# Altium schematic sheet 06_Ethernet_Memory_Expansion.SchDoc
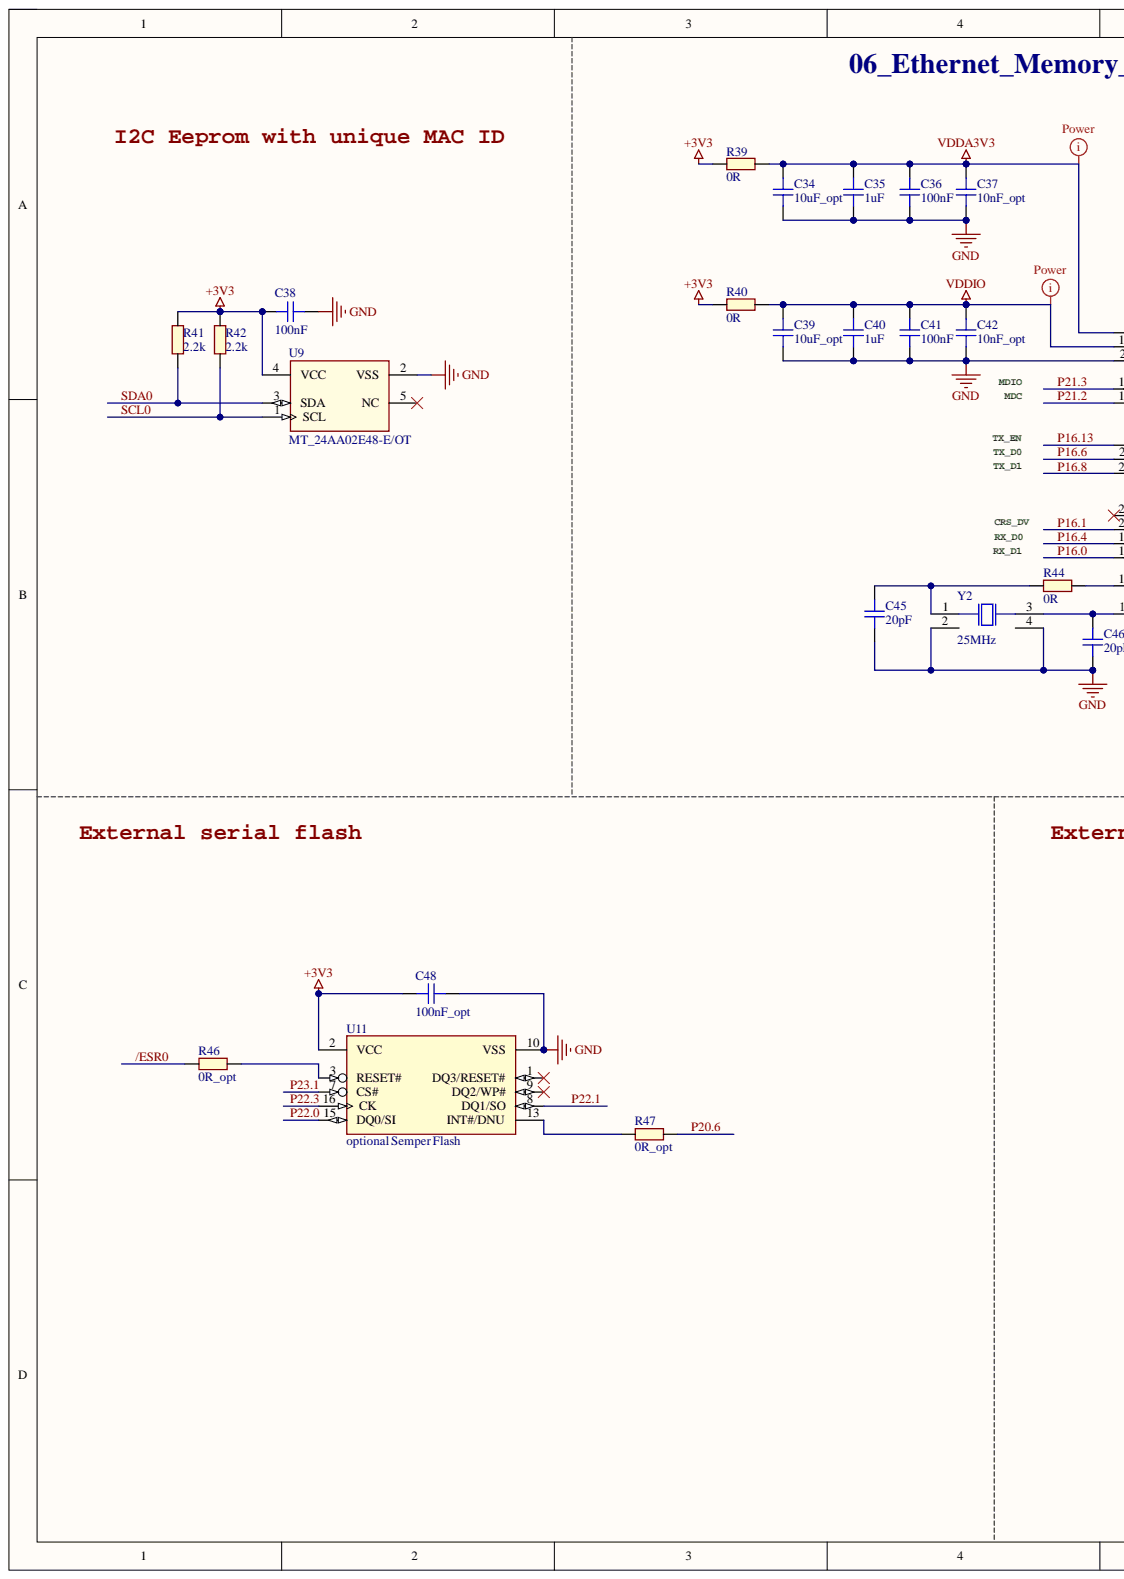
[diagram p0: 06_Ethernet_Memory_Expansion.SchDoc, left]
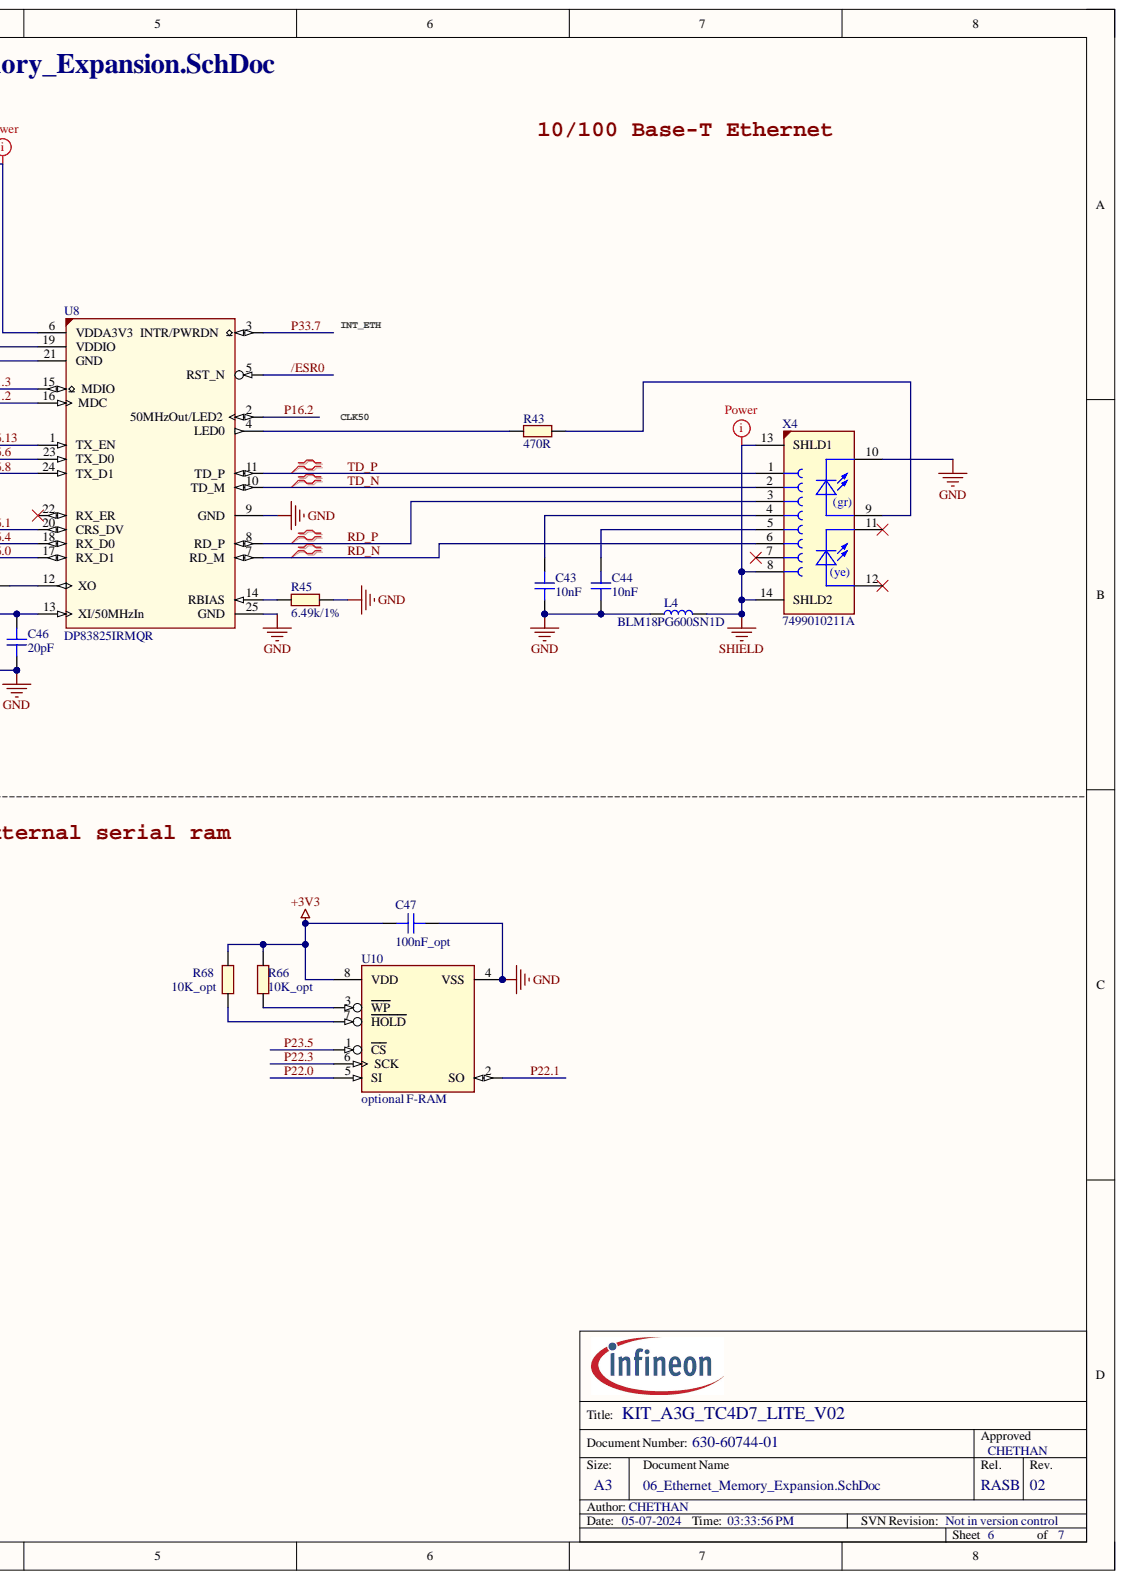
[diagram p1: 06_Ethernet_Memory_Expansion.SchDoc, right]

## Parts
C34  10uF_opt  [CAPC1005X70N]
C35  1uF  [CAPC1005X55N]
C36  100nF  [CAPC1005X55N-1]
C37  10nF_opt  [CAPC1005X55N]
C38  100nF  [CAPC1005X55N-1]
C39  10uF_opt  [CAPC1005X70N]
C40  1uF  [CAPC1005X55N]
C41  100nF  [CAPC1005X55N-1]
C42  10nF_opt  [CAPC1005X55N]
C43  10nF  [CAPC1005X55N]
C44  10nF  [CAPC1005X55N]
C45  20pF  [CAPC1005X55N-0]
C46  20pF  [CAPC1005X55N-0]
C47  100nF_opt  [CAPC1608X90N-4]
C48  100nF_opt  [CAPC1608X90N-4]
L4  BLM18PG600SN1D  [INDC1608X95N-2]
R39  0R  [RESC1609X50N]
R40  0R  [RESC1609X50N]
R41  2.2k  [RESC1609X50N]
R42  2.2k  [RESC1609X50N]
R43  470R  [RESC1005X40N]
R44  0R  [RESC1609X50N]
R45  6.49k/1%  [RESC1005X40N]
R46  0R_opt  [RESC1609X50N]
R47  0R_opt  [RESC1609X50N]
R66  10K_opt  [RESC1609X50N]
R68  10K_opt  [RESC1609X50N]
U8  DP83825IRMQR  [QFN40P300X300X80-25N]
U9  MT_24AA02E48-E/OT  [SOT95P270X145-5N-1]
U10  optional F-RAM  [SOIC127P800X203-8N]
U11  optional Semper Flash  [SOIC127P1030X265-16N-1]
X4  7499010211A  [CON-THT-RJ45-7499010211A]
Y2  25MHz  [XTAL-SMD-FA-238_1]

## Nets
+3V3: C38.1 C47.1 C48.1 R39.1 R40.1 R41.1 R42.1 R66.2 R68.2 U9.4(VCC) U10.8(VDD) U11.2(VCC)
GND: C34.1 C35.2 C36.1 C37.2 C38.2 C39.1 C40.2 C41.2 C42.2 C43.2 C44.2 C45.2 C46.2 C47.2 C48.2 L4.1 R45.2 U8.9(GND) U8.21(GND) U8.25(GND) U9.2(VSS) U10.4(VSS) U11.10(VSS) X4.10 Y2.2 Y2.4
SHIELD: L4.2 X4.8 X4.13(SHLD1) X4.14(SHLD2)
VDDA3V3: C34.2 C35.1 C36.2 C37.1 R39.2 U8.6(VDDA3V3)
VDDIO: C39.2 C40.1 C41.1 C42.1 R40.2 U8.19(VDDIO)
/ESR0: R46.2 U8.5(RST_N)
P16.0: U8.17(RX_D1)
P16.1: U8.20(CRS_DV)
P16.2: U8.2(50MHzOut/LED2)
P16.4: U8.18(RX_D0)
P16.6: U8.23(TX_D0)
P16.8: U8.24(TX_D1)
P16.13: U8.1(TX_EN)
P20.6: R47.1
P21.2: U8.16(MDC)
P21.3: U8.15(MDIO)
P22.0: U10.5(SI) U11.15(DQ0/SI)
P22.1: U10.2(SO) U11.8(DQ1/SO)
P22.3: U10.6(SCK) U11.16(CK)
P23.1: U11.7(CS#)
P23.5: U10.1(CS)
P33.7: U8.3(INTR/PWRDN)
RD_N: U8.7(RD_M) X4.6
RD_P: U8.8(RD_P) X4.3
SCL0: R42.2 U9.1(SCL)
SDA0: R41.2 U9.3(SDA)
TD_N: U8.10(TD_M) X4.2
TD_P: U8.11(TD_P) X4.1
NetC43_1: C43.1 X4.4
NetC44_1: C44.1 X4.5
NetC45_1: C45.1 R44.1 Y2.1
NetC46_1: C46.1 U8.13(XI/50MHzIn) Y2.3
NetR43_1: R43.1 U8.4(LED0)
NetR43_2: R43.2 X4.9
NetR44_2: R44.2 U8.12(XO)
NetR45_1: R45.1 U8.14(RBIAS)
NetR46_1: R46.1 U11.3(RESET#)
NetR47_2: R47.2 U11.13(INT#/DNU)
NetR66_1: R66.1 U10.3(WP)
NetR68_1: R68.1 U10.7(HOLD)

Not connected: U8 22(RX_ER); U9 5(NC); U11 1(DQ3/RESET#) 9(DQ2/WP#); X4 7 11 12

## Library data of the parts
C34 C39 = 310655: 10 µF ±20% 10V Ceramic Capacitor X5R 0402 (1005 Metric); Manufacturer: Samsung; Manufacturer Component Status: Active; Manufacturer Order Number: CL05A106MP5NUNC; Alternate View: Yes; Automotive Qualified: No; Combined Parameters: TC:X5R (EIA);Tol.:-20%/+20%;Vdc:10V; Legacy DeviceID: CAP01010925; Package Imperial: 0402; Package Metric: 1005; QuickPick: 10uF / 10V / 20% / X5R (EIA) / 0402; Style: Single; Symbol Type: Capacitor; Single; Standard; 2 Pins; Type: Standard; Value: 10uF; Version Number: V01; Article Number: 310655; Component Kind: Standard; ComponentLink1Description: Vendor WebSite; ComponentLink1URL: http://www.samsungsem.co.kr/; ComponentLink2Description: Datasheet; ComponentLink2URL: http://webnetprod.muc.infineon.com/PCBPortal_Prod/WorkFlows/AltiumComponent/StandardDocumentation?Versionid=310656; Legacy PartNumber: CAP01010925; Multiple Parts: No; Package Description: Capacitor,non-polarized,Chip;1.00mm L X 0.50mm W X 0.70mm H; PackageReference: 0402; Part Type: Capacitor; PLMStatus: Active; ROHS Compliant: Y; Second Source allowed: Yes; Supplier 1: Farnell; Supplier 2: DigiKey; Supplier 3: Mouser; Supplier Part Number 2: 1276-1450-1-ND; Supplier Part Number 3: Not Assigned; Technology: Ceramic; Temperature max: 85; Temperature min: -55; Temperature Range: Military; Tolerance Neg: 20%; Tolerance Pos: 20%; Voltage DC (max): 10V; Library Name: AURIX_Lite_Kit_V2.0.SCHLIB
C35 C40 = 309460: 1 µF ±10% 10V Ceramic Capacitor X5R 0402 (1005 Metric); Manufacturer: Kemet; Manufacturer Order Number: C0402C105K8PACTU; Alternate View: Yes; Automotive Qualified: No; Combined Parameters: TC:X5R (EIA);Tol.:-10%/+10%;Vdc:10V; Legacy DeviceID: CAP01010765; Package Imperial: 0402; Package Metric: 1005; PLMStatus: Active; QuickPick: 1uF / 10V / 10% / X5R (EIA) / 0402 (1005); Style: Single; Symbol Type: Capacitor; Single; Standard; 2 Pins; Type: Standard; Version Number: V01; Article Number: 309460; Component Kind: Standard; ComponentLink1Description: Vendor WebSite; ComponentLink1URL: http://www.kemet.com; ComponentLink2Description: Datasheet; ComponentLink2URL: http://webnetprod.muc.infineon.com/PCBPortal_Prod/WorkFlows/AltiumComponent/StandardDocumentation?Versionid=309461; Legacy PartNumber: CAP01010765; Multiple Parts: No; Package Description: Capacitor,non-polarized,Chip;1.00mm L X 0.50mm W X 0.55mm H; PackageReference: 0402 (1005); Part Type: Capacitor; ROHS Compliant: Y; Second Source allowed: Yes; Supplier 1: Farnell; Supplier 2: DigiKey; Supplier 3: Mouser; Supplier Part Number 1: 2392237; Supplier Part Number 2: 399-C0402C105K8PAC7867CT-ND; Supplier Part Number 3: 80-C0402C105K8P; Technology: Ceramic; Temperature max: 85; Temperature min: -55; Temperature Range: Military; Tolerance Neg: 10%; Tolerance Pos: 10%; Value: 1uF; Voltage DC (max): 10V; Library Name: AURIX_Lite_Kit_V2.0.SCHLIB
C36 C38 C41 = 308680: 0.1 µF ±10% 16V Ceramic Capacitor X7R 0402 (1005 Metric); Manufacturer: TDK Corporation; Manufacturer Component Status: Active; Manufacturer Order Number: CGA2B1X7R1C104K050BC; Multiple Parts: No; Alternate View: Yes; Automotive Qualified: AEC Q200; Combined Parameters: TC:X7R (EIA);Tol.:-10%/+10%;Vdc:16V; Legacy DeviceID: CAP01010669; Package Imperial: 0402; Package Metric: 1005; QuickPick: 100nF / 16V / 10% / X7R (EIA) / 0402 (1005); Style: Single; Symbol Type: Capacitor; Single; Standard; 2 Pins; Type: Standard; Version Number: V01; Article Number: 308680; Component Kind: Standard; ComponentLink1Description: Vendor WebSite; ComponentLink1URL: http://www.tdk.com; ComponentLink2Description: Datasheet; ComponentLink2URL: http://webnetprod.muc.infineon.com/PCBPortal_Prod/WorkFlows/AltiumComponent/StandardDocumentation?Versionid=308681; Legacy PartNumber: CAP01010669; Package Description: Capacitor,non-polarized,Chip;1.00mm L X 0.50mm W X 0.55mm H; PackageReference: 0402 (1005); Part Type: Capacitor; PLMStatus: Active; ROHS Compliant: Y; Second Source allowed: Yes; Supplier 1: Farnell; Supplier 2: DigiKey; Supplier 3: Mouser; Supplier Part Number 1: 2458205; Supplier Part Number 2: 445-5613-1-ND; Supplier Part Number 3: 810-CGA2B1X7R1C104K; Technology: Ceramic; Temperature max: 125; Temperature min: -55; Temperature Range: Military; Tolerance Neg: 10%; Tolerance Pos: 10%; Value: 100nF; Voltage DC (max): 16V; Library Name: AURIX_Lite_Kit_V2.0.SCHLIB
C37 C42 = 308900: 10000 pF ±10% 16V Ceramic Capacitor X7R 0402 (1005 Metric); Manufacturer: Kemet; Manufacturer Order Number: C0402C103K4RACAUTO; Multiple Parts: No; Alternate View: Yes; Automotive Qualified: AEC Q200; Combined Parameters: TC:X7R (EIA);Tol.:-10%/+10%;Vdc:16V; Legacy DeviceID: CAP01010696; Package Imperial: 0402; Package Metric: 1005; PLMStatus: Active; QuickPick: 10nF / 16V / 10% / X7R (EIA) / 0402 (1005); Style: Single; Symbol Type: Capacitor; Single; Standard; 2 Pins; Type: Standard; Value: 10nF; Version Number: V01; Article Number: 308900; Component Kind: Standard; ComponentLink1Description: Vendor WebSite; ComponentLink1URL: http://www.kemet.com; ComponentLink2Description: Datasheet; ComponentLink2URL: http://webnetprod.muc.infineon.com/PCBPortal_Prod/WorkFlows/AltiumComponent/StandardDocumentation?Versionid=308901; Legacy PartNumber: CAP01010696; Package Description: CAP CER 10000PF 16V X7R 0402; PackageReference: 0402 (1005); Part Type: Capacitor; ROHS Compliant: Y; Second Source allowed: Yes; Supplier 1: Farnell; Supplier 2: DigiKey; Supplier 3: Mouser; Supplier Part Number 1: 2478229RL; Supplier Part Number 2: 399-6825-1-ND; Supplier Part Number 3: 80-C0402C103K4RAUTO; Technology: Ceramic; Temperature max: 125; Temperature min: -55; Temperature Range: Military; Tolerance Neg: 10%; Tolerance Pos: 10%; Voltage DC (max): 16V; Library Name: AURIX_Lite_Kit_V2.0.SCHLIB
C43 C44 = 308900: 10000 pF ±10% 16V Ceramic Capacitor X7R 0402 (1005 Metric); Manufacturer: Kemet; Manufacturer Order Number: C0402C103K4RACAUTO; Multiple Parts: No; Alternate View: Yes; Automotive Qualified: AEC Q200; Combined Parameters: TC:X7R (EIA);Tol.:-10%/+10%;Vdc:16V; Legacy DeviceID: CAP01010696; Package Imperial: 0402; Package Metric: 1005; PLMStatus: Active; QuickPick: 10nF / 16V / 10% / X7R (EIA) / 0402 (1005); Style: Single; Symbol Type: Capacitor; Single; Standard; 2 Pins; Type: Standard; Version Number: V01; Article Number: 308900; Component Kind: Standard; ComponentLink1Description: Vendor WebSite; ComponentLink1URL: http://www.kemet.com; ComponentLink2Description: Datasheet; ComponentLink2URL: http://webnetprod.muc.infineon.com/PCBPortal_Prod/WorkFlows/AltiumComponent/StandardDocumentation?Versionid=308901; Legacy PartNumber: CAP01010696; Package Description: Capacitor,non-polarized,Chip;1.00mm L X 0.50mm W X 0.55mm H; PackageReference: 0402 (1005); Part Type: Capacitor; ROHS Compliant: Y; Second Source allowed: Yes; Supplier 1: Farnell; Supplier 2: DigiKey; Supplier 3: Mouser; Supplier Part Number 1: 2478229RL; Supplier Part Number 2: 399-6825-1-ND; Supplier Part Number 3: 80-C0402C103K4RAUTO; Technology: Ceramic; Temperature max: 125; Temperature min: -55; Temperature Range: Military; Tolerance Neg: 10%; Tolerance Pos: 10%; Value: 10nF; Voltage DC (max): 16V; Library Name: AURIX_Lite_Kit_V2.0.SCHLIB
C45 C46 = 308044: 20 pF ±2% 50V Ceramic Capacitor C0G, NP0 0402 (1005 Metric); Manufacturer: MuRata; Manufacturer Order Number: GRM1555C1H200GA01; Alternate View: Yes; Automotive Qualified: No; Combined Parameters: TC:C0G (EIA) / NP0;Tol.:-2%/+2%;Vdc:50V; Legacy DeviceID: CAP01010598; Package Imperial: 0402; Package Metric: 1005; PLMStatus: Active; QuickPick: 20pF / 50V / 2% / C0G (EIA) / NP0 / 0402; Style: Single; Symbol Type: Capacitor; Single; Standard; 2 Pins; Type: Standard; Version Number: V01; Article Number: 308044; Component Kind: Standard; ComponentLink1Description: Vendor WebSite; ComponentLink1URL: http://www.murata.com/; ComponentLink2Description: Datasheet; ComponentLink2URL: http://webnetprod.muc.infineon.com/PCBPortal_Prod/WorkFlows/AltiumComponent/StandardDocumentation?Versionid=308045; Legacy PartNumber: CAP01010598; Multiple Parts: No; Package Description: Capacitor,non-polarized,Chip;1.00mm L X 0.50mm W X 0.55mm H; PackageReference: 0402; Part Type: Capacitor; ROHS Compliant: Y; Second Source allowed: Yes; Supplier 1: Farnell; Supplier 2: DigiKey; Supplier 3: Mouser; Supplier Part Number 2: 490-6217-2-ND; Supplier Part Number 3: 81-GRM1555C1H200GA1D; Technology: Ceramic; Temperature max: 125; Temperature min: -55; Temperature Range: Military; Tolerance Neg: 2%; Tolerance Pos: 2%; Value: 20pF; Voltage DC (max): 50V; Library Name: AURIX_Lite_Kit_V2.0.SCHLIB
C47 C48 = 497792: 0.1 µF ±20% 100V Ceramic Capacitor X7S 0603 (1608 Metric); Manufacturer: TDK Corporation; Manufacturer Order Number: CGA3E3X7S2A104M080AB; Multiple Parts: No; Alternate View: Yes; Automotive Qualified: AEC Q200; Combined Parameters: TC:X7S (EIA);Tol.:-20%/+20%;Vdc:100V; Package Imperial: 0603; Package Metric: 1608; PLMStatus: Active; QuickPick: 100nF / 100V / 20% / X7S (EIA) / 0603; Style: Single; Symbol Type: Capacitor; Single; Standard; 2 Pins; Type: Standard; Value: 100nF; Version Number: V01; Application: Standard; Article Number: 497792; Component Kind: Standard; ComponentLink1Description: Vendor WebSite; ComponentLink1URL: http://www.tdk.com; ComponentLink2Description: Datasheet; ComponentLink2URL: http://webnetprod.muc.infineon.com/PCBPortal_Prod/WorkFlows/AltiumComponent/StandardDocumentation?Versionid=497793; Package Description: Capacitor,non-polarized,Chip;1.60mm L X 0.80mm W X 0.90mm H; PackageReference: 0603; Part Type: Capacitor; ROHS Compliant: Y; Second Source allowed: Yes; Supplier 1: Farnell; Supplier 2: DigiKey; Supplier 3: Mouser; Supplier Part Number 1: 2803337; Supplier Part Number 2: 445-12576-1-ND; Supplier Part Number 3: 810-CGA3E3X7S2A104AB; Technology: Ceramic; Temperature max: 125; Temperature min: -55; Temperature Range: Military; Tolerance Neg: 20%; Tolerance Pos: 20%; Voltage DC (max): 100V; Library Name: AURIX_Lite_Kit_V2.0.SCHLIB
L4 = 526189: 60 Ohms @ 100 MHz 1 Power Line Ferrite Bead 0603 (1608 Metric) 500mA 100mOhm; Manufacturer: MuRata; Alternate View: Yes; Automotive Qualified: No; Combined Parameters: Tol.:-;Rdc:100mR;Idc:1A;Fres:-; PLMStatus: Active; QuickPick: 60R / Ferrite Bead / 603; Style: Single; Symbol Type: Inductor; Single; Standard; 2 Pins; Value: 60R; Version Number: V01; Voltage DC (max): na; Application: Power Electronics; Article Number: 526189; Component Kind: Standard; ComponentLink1Description: Vendor WebSite; ComponentLink1URL: http://www.murata.com/; ComponentLink2Description: Datasheet; ComponentLink2URL: http://webnetprod.muc.infineon.com/PCBPortal_Prod/WorkFlows/AltiumComponent/StandardDocumentation?Versionid=526190; Manufacturer Order Number: BLM18PG600SN1D; Multiple Parts: No; Package Description: Inductor,Chip;1.60mm L X 0.80mm W X 0.95mm H; PackageReference: 603; Part Type: Inductor; ROHS Compliant: Y; Second Source allowed: Yes; Supplier 1: Farnell; Supplier 2: DigiKey; Supplier 3: Mouser; Supplier Part Number 1: 1515746; Supplier Part Number 2: 490-1036-1-ND; Supplier Part Number 3: 81-BLM11P600S; Temperature max: 125; Temperature min: -55; Temperature Range: Military; Type: Ferrite Bead; Library Name: AURIX_Lite_Kit_V2.0.SCHLIB
R39 R40 R44 = 343882: 0 Ohms Jumper Chip Resistor 0603 (1608 Metric) Automotive AEC-Q200, Pulse Withstanding Thick Film; Manufacturer: Vishay; Manufacturer Order Number: CRCW06030000Z0EAHP; Multiple Parts: No; Alternate View: Yes; Automotive Qualified: AEC Q200; Combined Parameters: TCR:-;Tol.:-0R/+0R;Vdc:75V;Idc:2A;P:-; Legacy DeviceID: RES01800800; PLMStatus: Active; QuickPick: 0R / 0R / 0603; Style: Single; Symbol Type: Resistor; Single; Standard; 2 Pins; Version Number: V01; Voltage DC (max): 75V; Article Number: 343882; Component Kind: Standard; ComponentLink1Description: Vendor WebSite; ComponentLink1URL: http://www.vishay.com; ComponentLink2Description: Datasheet; ComponentLink2URL: http://webnetprod.muc.infineon.com/PCBPortal_Prod/WorkFlows/AltiumComponent/StandardDocumentation?Versionid=343883; Legacy PartNumber: RES01800800; PackageReference: 0603; Part Type: Resistor; ROHS Compliant: Y; Second Source allowed: Yes; Supplier 1: Farnell; Supplier 2: DigiKey; Supplier 3: Mouser; Supplier Part Number 2: 541-0.0SBCT-ND; Supplier Part Number 3: 71-CRCW06030000Z0EAH; Technology: Thick Film; Temperature max: 155; Temperature min: -55; Temperature Range: Military; Tolerance Neg: 0R; Tolerance Pos: 0R; Type: Standard; Value: 0R; Library Name: AURIX_Lite_Kit_V2.0.SCHLIB
R41 R42 = 345782: 2.2 kOhms ±1% 0.1W, 1/10W Chip Resistor 0603 (1608 Metric) Automotive AEC-Q200 Thick Film; Manufacturer: Vishay; Manufacturer Order Number: CRCW06032K20FKTA; Multiple Parts: No; Alternate View: Yes; Automotive Qualified: AEC Q200; Combined Parameters: TCR:100ppm/K;Tol.:-1%/+1%;Vdc:75V;Idc:-;P:100mW; Legacy DeviceID: RES01801179; PLMStatus: Active; QuickPick: 2.2k / 1% / 0.10 / 0603; Style: Single; Symbol Type: Resistor; Single; Standard; 2 Pins; Version Number: V01; Voltage DC (max): 75V; Article Number: 345782; Component Kind: Standard; ComponentLink1Description: Vendor WebSite; ComponentLink1URL: http://www.vishay.com; ComponentLink2Description: Datasheet; ComponentLink2URL: http://webnetprod.muc.infineon.com/PCBPortal_Prod/WorkFlows/AltiumComponent/StandardDocumentation?Versionid=345783; Legacy PartNumber: RES01801179; PackageReference: 0603; Part Type: Resistor; ROHS Compliant: Y; Second Source allowed: Yes; Supplier 1: Farnell; Supplier 2: DigiKey; Supplier 3: Mouser; Supplier Part Number 2: 541-CRCW06032K20FKTACT-ND; Supplier Part Number 3: 71-CRCW0603-2.2K; Technology: Thick Film; Temperature max: 155; Temperature min: -55; Temperature Range: Military; Tolerance Neg: 1%; Tolerance Pos: 1%; Type: Standard; Value: 2.2k; Library Name: AURIX_Lite_Kit_V2.0.SCHLIB
R43 = 341407: 470 Ohms ±1% 0.063W, 1/16W Chip Resistor 0402 (1005 Metric) Thick Film; Manufacturer: Vishay; Manufacturer Order Number: CRCW0402470RFKEDC; Multiple Parts: No; Alternate View: Yes; Automotive Qualified: AEC Q200; Combined Parameters: TCR:100ppm/K;Tol.:-1%/+1%;Vdc:50V;Idc:-;P:63mW; Legacy DeviceID: RES01800305; PLMStatus: Active; QuickPick: 470R / 1% / 0.0630 / 0402; Style: Single; Symbol Type: Resistor; Single; Standard; 2 Pins; Version Number: V01; Voltage DC (max): 50V; Article Number: 341407; Component Kind: Standard; ComponentLink1Description: Vendor WebSite; ComponentLink1URL: http://www.vishay.com; ComponentLink2Description: Datasheet; ComponentLink2URL: http://webnetprod.muc.infineon.com/PCBPortal_Prod/WorkFlows/AltiumComponent/StandardDocumentation?Versionid=341408; Legacy PartNumber: RES01800305; PackageReference: 0402; Part Type: Resistor; ROHS Compliant: Y; Second Source allowed: Yes; Supplier 1: Farnell; Supplier 2: DigiKey; Supplier 3: Mouser; Supplier Part Number 2: 541-4072-1-ND; Technology: Thick Film; Temperature max: 155; Temperature min: -55; Temperature Range: Military; Tolerance Neg: 1%; Tolerance Pos: 1%; Type: Standard; Value: 470R; Library Name: AURIX_Lite_Kit_V2.0.SCHLIB
R45 = 342062: 6.49 kOhms ±1% 0.063W, 1/16W Chip Resistor 0402 (1005 Metric) Automotive AEC-Q200 Thick Film; Manufacturer: Vishay; Manufacturer Order Number: CRCW04026K49FKED; Multiple Parts: No; Alternate View: Yes; Automotive Qualified: AEC Q200; Combined Parameters: TCR:100ppm/K;Tol.:-1%/+1%;Vdc:50V;Idc:-;P:63mW; Legacy DeviceID: RES01800436; PLMStatus: Active; QuickPick: 6.49k / 1% / 0.0630 / 0402; Style: Single; Symbol Type: Resistor; Single; Standard; 2 Pins; Value: 6.49k; Version Number: V01; Voltage DC (max): 50V; Article Number: 342062; Component Kind: Standard; ComponentLink1Description: Vendor WebSite; ComponentLink1URL: http://www.vishay.com; ComponentLink2Description: Datasheet; ComponentLink2URL: http://webnetprod.muc.infineon.com/PCBPortal_Prod/WorkFlows/AltiumComponent/StandardDocumentation?Versionid=342063; Legacy PartNumber: RES01800436; PackageReference: 0402; Part Type: Resistor; ROHS Compliant: Y; Second Source allowed: Yes; Supplier 1: Farnell; Supplier 2: DigiKey; Supplier 3: Mouser; Supplier Part Number 2: 541-6.49KLCT-ND; Technology: Thick Film; Temperature max: 155; Temperature min: -55; Temperature Range: Military; Tolerance Neg: 1%; Tolerance Pos: 1%; Type: Standard; Library Name: AURIX_Lite_Kit_V2.0.SCHLIB
R46 R47 = 343882: 0 Ohms Jumper Chip Resistor 0603 (1608 Metric) Automotive AEC-Q200, Pulse Withstanding Thick Film; Manufacturer: Vishay; Manufacturer Order Number: CRCW06030000Z0EAHP; Multiple Parts: No; Alternate View: Yes; Automotive Qualified: AEC Q200; Combined Parameters: TCR:-;Tol.:-0R/+0R;Vdc:75V;Idc:2A;P:-; Legacy DeviceID: RES01800800; PLMStatus: Active; QuickPick: 0R / 0R / 0603; Style: Single; Symbol Type: Resistor; Single; Standard; 2 Pins; Tolerance Neg: 0R; Value: 0R; Version Number: V01; Voltage DC (max): 75V; Article Number: 343882; Component Kind: Standard; ComponentLink1Description: Vendor WebSite; ComponentLink1URL: http://www.vishay.com; ComponentLink2Description: Datasheet; ComponentLink2URL: http://webnetprod.muc.infineon.com/PCBPortal_Prod/WorkFlows/AltiumComponent/StandardDocumentation?Versionid=343883; Legacy PartNumber: RES01800800; PackageReference: 0603; Part Type: Resistor; ROHS Compliant: Y; Second Source allowed: Yes; Supplier 1: Farnell; Supplier 2: DigiKey; Supplier 3: Mouser; Supplier Part Number 2: 541-0.0SBCT-ND; Supplier Part Number 3: 71-CRCW06030000Z0EAH; Technology: Thick Film; Temperature max: 155; Temperature min: -55; Temperature Range: Military; Tolerance Pos: 0R; Type: Standard; Library Name: AURIX_Lite_Kit_V2.0.SCHLIB
R66 R68 = 343882: 10 kOhms ±5% 0.1W, 1/10W Chip Resistor 0603 (1608 Metric) Automotive AEC-Q200 Thick Film; Manufacturer: Vishay; Manufacturer Order Number: CRCW060310K0JNEA; Multiple Parts: No; Alternate View: Yes; Automotive Qualified: AEC Q200; Combined Parameters: TCR:-;Tol.:-0R/+0R;Vdc:75V;Idc:2A;P:-; Legacy DeviceID: RES01800800; PLMStatus: Active; QuickPick: 0R / 0R / 0603; Style: Single; Symbol Type: Resistor; Single; Standard; 2 Pins; Tolerance Neg: 0R; Value: 10k; Version Number: V01; Voltage DC (max): 75V; Article Number: 343882; Component Kind: Standard; ComponentLink1Description: Vendor WebSite; ComponentLink1URL: http://www.vishay.com; ComponentLink2Description: Datasheet; ComponentLink2URL: http://webnetprod.muc.infineon.com/PCBPortal_Prod/WorkFlows/AltiumComponent/StandardDocumentation?Versionid=343883; Legacy PartNumber: RES01800800; PackageReference: 0603; Part Type: Resistor; ROHS Compliant: Y; Second Source allowed: Yes; Supplier 1: Farnell; Supplier 2: DigiKey; Supplier 3: Mouser; Supplier Part Number 2: 541-10KGCT-ND; Technology: Thick Film; Temperature max: 155; Temperature min: -55; Temperature Range: Military; Tolerance Pos: 0R; Type: Standard; Library Name: AURIX_Lite_Kit_V2.0.SCHLIB
U8 = 409425_NEW: Low Power 10/100 Mbps Ethernet Physical Layer Transceiver, 10Base-T/100Base-TX PHY with RMII with 25MHz crystal/clock input and 50MHz RMII REF_CLK output, Industrial Temperature; Manufacturer: Texas Instruments; Alternate View: Yes; Automotive Qualified: No; Combined Parameters: Standard Interface Interface/Transceiver;Vdc min/max:3.14V/3.47V;Iout:-;Fmax:50Hz; Legacy DeviceID: TI_DP83825IRMQR; PLMStatus: Active; QuickPick: WQFN-24; Symbol Type: 10Base-T/100Base-TX PHY with RMII Support; Version Number: V01; Voltage DC (max): na; Application: Standard Interface; Article Number: 409425_NEW; Component Kind: Standard; ComponentLink1Description: Vendor WebSite; ComponentLink1URL: http://www.ti.com; ComponentLink2Description: Datasheet; ComponentLink2URL: https://www.ti.com/lit/ds/symlink/dp83825i.pdf; Manufacturer Order Number: DP83825IRMQR; Package Description: WQFN,0.50mm pitch,square;24 pin,3.00mm L X 3.00mm W X 0.80mm H body (w/thermal tab); PackageReference: WQFN-24; Part Type: Integrated Circuit; ROHS Compliant: Y; Second Source allowed: Yes; Supplier 1: Texas Instruments; Supplier 2: DigiKey; Supplier 3: Mouser; Supplier Part Number 1: DP83825IRMQR; Supplier Part Number 2: 296-DP83825IRMQRCT-ND; Supplier Part Number 3: 595-DP83825IRMQR; Temperature max: 85; Temperature min: -40; Temperature Range: Industrial; Type: Interface/Transceiver; Value: DP83825IRMQR; Library Name: AURIX_4x7_Lite_Kit.SCHLIB
U9 = 448388: EEPROM Memory IC 2Kbit I²C 400 kHz 900 ns SOT-23-5; Legacy PartNumber: IC02601202; Manufacturer: Microchip Technology; Manufacturer Order Number: 24AA02E48T-E/OT; Alternate View: No; Automotive Qualified: Yes; Combined Parameters: -;-;1I/Os; PLMStatus: Active; QuickPick: 1 / 1.70V - 5.50V / SOT23-5; Symbol Type: 2K I2C Serial EEPROM; Version Number: V01; Voltage DC (max): na; Article Number: 448388; Component Kind: Standard; ComponentLink1Description: Vendor WebSite; ComponentLink1URL: http://www.microchip.com/; ComponentLink2Description: Datasheet; ComponentLink2URL: http://webnetprod.muc.infineon.com/PCBPortal_Prod/WorkFlows/AltiumComponent/StandardDocumentation?Versionid=448389; Legacy DeviceID: MT_24AA02E48-E/OT; Multiple Parts: No; Package Description: SOT,0.95mm pitch;5 pin,1.55mm W X 2.90mm L X 1.45mm H body; PackageReference: SOT23-5; Part Type: PLD; ROHS Compliant: Y; Second Source allowed: Yes; Supplier 1: Farnell; Supplier 2: DigiKey; Supplier 3: Mouser; Supplier Part Number 2: 24AA02E48T-E/OTCT-ND; Temperature max: 125; Temperature min: -40; Temperature Range: Automotive; Value: MT_24AA02E48-E/OT; Library Name: AURIX_Lite_Kit_V2.0.SCHLIB
U10 = 482475_NEW: FRAM (Ferroelectric RAM) Memory IC 4Mbit SPI 40 MHz 8-SOIC; Manufacturer: Infineon Technologies; Manufacturer Order Number: 448-CY15B104Q-SXI-ND; Alternate View: No; Legacy DeviceID: CYP_CY15B104Q-S; PLMStatus: Active; Second Source allowed: Yes; Symbol Type: 4-Mbit (512 K × 8) Serial (SPI) F-RAM; Value: CY15B104Q-SXI; Version Number: V01; Voltage DC (max): na; Article Number: 482475_NEW; Component Kind: Standard; ComponentLink1Description: Vendor WebSite; ComponentLink1URL: http://www.cypress.com; ComponentLink2Description: Datasheet; ComponentLink2URL: https://www.cypress.com/file/209146/download; Multiple Parts: No; Package Description: SOIC,1.27mm pitch;8 pin,3.90mm W X 4.89mm L X 1.73mm H body; PackageReference: SOIC-8; Part Type: Memory; ROHS Compliant: Y; Supplier 1: Farnell; Supplier 2: DigiKey; Supplier 3: Mouser; Supplier Part Number 1: 2749232; Supplier Part Number 2: 448-CY15B104Q-SXI-ND; Supplier Part Number 3: 727-CY15B104Q-SXI; Temperature max: 85; Temperature min: -40; Temperature Range: Industrial; Type: Memory Controller; Library Name: AURIX_4x7_Lite_Kit.SCHLIB
U11 = 408252_NEW: FLASH - NOR Memory IC 512Mbit SPI - Quad I/O 133 MHz 16-SOIC; Manufacturer: Cypress Semiconductor; Manufacturer Order Number: S25HL512TDPMHI010; Automotive Qualified: No; Legacy DeviceID: CY_S25HL512TDPMHI010; PLMStatus: Active; Second Source allowed: Yes; Symbol Type: 32-Mbit CMOS 3.0 Volt Flash Memory  with 104-MHz SPI (Serial Peripheral Interface) Multi I/O Bus; Value: S25HL512TDPMHI010; Version Number: V01; Voltage DC (max): na; Article Number: 408252_NEW; Component Kind: Standard; ComponentLink1Description: Vendor WebSite; ComponentLink1URL: http://www.cypress.com; ComponentLink2Description: Datasheet; ComponentLink2URL: https://www.cypress.com/file/506771/download; PackageReference: SO-16; Part Type: Memory; Supplier 1: Mouser; Supplier 2: Digi-Key; Supplier 3: Farnell; Supplier Part Number 1: 727-S25HL512TDPMHI01; Supplier Part Number 2: 428-S25HL512TDPMHI010-ND; Supplier Part Number 3: 3288075; Temperature Range: Military; Library Name: AURIX_Lite_Kit_V2.0.SCHLIB
X4 = 384756: 1 Port RJ45Through Hole 10/100 Base-T, AutoMDIX; Legacy PartNumber: CON01500755; Manufacturer: Wurth Elektronik; Alternate View: Yes; Automotive Qualified: No; Combined Parameters: Board-to-Cable Socket (female);-x-;Mounting:THT;Orient:90Â° (right angle);Shielded:Yes; Legacy DeviceID: WE_7499010211A; PLMStatus: Active; QuickPick: 90Â° (right angle) / Board-to-Cable; Style: Western Jack; Symbol Type: RJ45 Connector with integrated transformer, 8 positions, 8 contacts, 2 LEDs (green, yellow), Shielded; Version Number: V01; Voltage DC (max): na; Article Number: 384756; Component Kind: Standard; ComponentLink1Description: Vendor WebSite; ComponentLink1URL: http://www.we-online.com; ComponentLink2Description: Datasheet; ComponentLink2URL: http://webnetprod.muc.infineon.com/PCBPortal_Prod/WorkFlows/AltiumComponent/StandardDocumentation?Versionid=384757; Manufacturer Order Number: 7499010211A; Multiple Parts: Yes; Package Description: RJ45 THT Connector, 2.54mm pitch; 15.88mm L X 21.84mm W X 13.50mm H body; Part Type: Connector; ROHS Compliant: Y; Second Source allowed: Yes; Supplier 1: Farnell; Supplier 2: DigiKey; Supplier 3: Mouser; Supplier Part Number 1: 2356127; Supplier Part Number 2: 732-4506-5-ND; Supplier Part Number 3: 710-7499010211A; Temperature max: 70; Temperature min: 0; Temperature Range: Commercial; Type: Board-to-Cable; Value: 7499010211A; Library Name: AURIX_Lite_Kit_V2.0.SCHLIB
Y2 = 410425: 25 MHz ±50ppm Crystal 18pF 50 Ohms 4-SMD, No Lead; Legacy PartNumber: IC02600683; Manufacturer: Epson; Manufacturer Order Number: FA-238 25.0000MB-C3; Combined Parameters: WLAN Standard Crystal;Tol.:-50%/+50%; Legacy DeviceID: ES_FA-238 25.0000MB-C3; PLMStatus: Active; QuickPick: 25000000 / Crystal; Second Source allowed: Yes; Style: Standard; Symbol type: Standard Crystal Symbol, 4 Pins; Tolerance Neg: 50%; Version Number: V01; Voltage DC (max): na; Application: WLAN; Article Number: 410425; Automotive Qualified: No; Component Kind: Standard; ComponentLink1Description: Vendor WebSite; ComponentLink1URL: http://www.epsondevice.com/; ComponentLink2Description: Datasheet; ComponentLink2URL: http://webnetprod.muc.infineon.com/PCBPortal_Prod/WorkFlows/AltiumComponent/StandardDocumentation?Versionid=410426; Package Description: Crystal; 3.2mm W X 2.5mm L X 0.7mm H body; PackageReference: SMD 3.2 x 2.5 x 0.7mm; Part Type: Clock; ROHS Compliant: Y; Supplier 1: Farnell; Supplier 2: DigiKey; Supplier 3: Mouser; Supplier Part Number 2: SER3691CT-ND; Supplier Part Number 3: 732-FA238-25B-C3; Temperature max: 85; Temperature min: -40; Temperature Range: Industrial; Tolerance Pos: 50%; Type: Crystal; Value: 25MHz; Library Name: AURIX_Lite_Kit_V2.0.SCHLIB
